# Altium schematic sheet Power_Relay.SchDoc
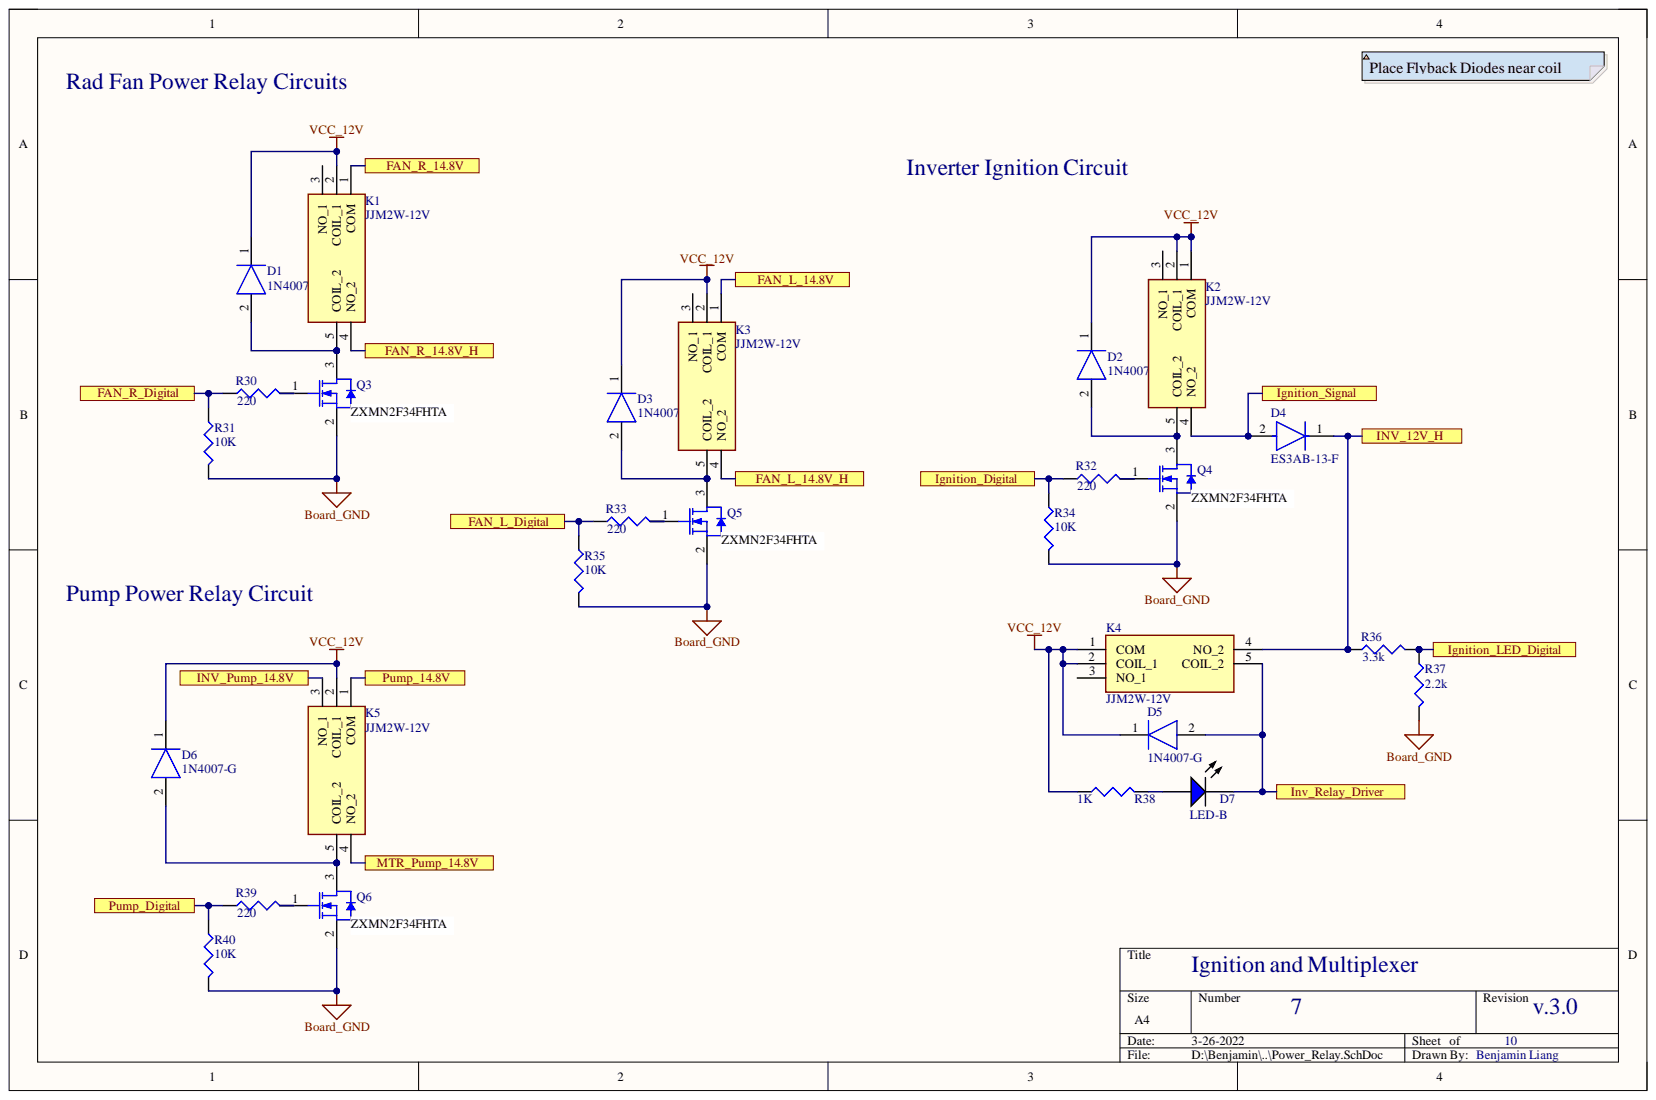
[diagram p0: Power_Relay.SchDoc]

## Parts
D1  1N4007-G  [DIOAD1410W90L470D235]
D2  1N4007-G  [DIOAD1410W90L470D235]
D3  1N4007-G  [DIOAD1410W90L470D235]
D4  ES3AB-13-F  [FP-SMB-MFG]
D5  1N4007-G  [DIOAD1410W90L470D235]
D6  1N4007-G  [DIOAD1410W90L470D235]
D7  LED-B  [LTST-C193TBKT_V]
K1  JJM2W-12V  [JJM2W12V]
K2  JJM2W-12V  [JJM2W12V]
K3  JJM2W-12V  [JJM2W12V]
K4  JJM2W-12V  [JJM2W12V]
K5  JJM2W-12V  [JJM2W12V]
Q3  ZXMN2F34FHTA  [FAIR-SSOT3L-3_V]
Q4  ZXMN2F34FHTA  [FAIR-SSOT3L-3_V]
Q5  ZXMN2F34FHTA  [FAIR-SSOT3L-3_V]
Q6  ZXMN2F34FHTA  [FAIR-SSOT3L-3_V]
R30  220  [J1-0603]
R31  10K  [J1-0603]
R32  220  [J1-0603]
R33  220  [J1-0603]
R34  10K  [J1-0603]
R35  10K  [J1-0603]
R36  3.3k  [J1-0603]
R37  2.2k  [J1-0603]
R38  1K  [J1-0603]
R39  220  [J1-0603]
R40  10K  [J1-0603]

## Ports
FAN_L_14.8V, FAN_L_14.8V_H, FAN_L_Digital, FAN_R_14.8V, FAN_R_14.8V_H, FAN_R_Digital, Ignition_Digital, Ignition_LED_Digital, Ignition_Signal, INV_12V_H, INV_Pump_14.8V, Inv_Relay_Driver, MTR_Pump_14.8V, Pump_14.8V, Pump_Digital

## Nets
Board_GND: Q3.2 Q4.2 Q5.2 Q6.2 R31.2 R34.2 R35.2 R37.2 R40.2
VCC_12V: D1.1 D2.1 D3.1 D5.1 D6.1 K1.2(COIL_1) K2.1(COM) K2.2(COIL_1) K3.2(COIL_1) K4.1(COM) K4.2(COIL_1) K5.2(COIL_1) R38.1
FAN_L_14.8V: K3.1(COM) ; port FAN_L_14.8V
FAN_L_14.8V_H: K3.4(NO_2) ; port FAN_L_14.8V_H
FAN_L_Digital: R33.1 R35.1 ; port FAN_L_Digital
FAN_R_14.8V: K1.1(COM) ; port FAN_R_14.8V
FAN_R_14.8V_H: K1.4(NO_2) ; port FAN_R_14.8V_H
FAN_R_Digital: R30.1 R31.1 ; port FAN_R_Digital
Ignition_Digital: R32.1 R34.1 ; port Ignition_Digital
Ignition_LED_Digital: R36.2 R37.1 ; port Ignition_LED_Digital
Ignition_Signal: D4.2 K2.4(NO_2) ; port Ignition_Signal
INV_12V_H: D4.1 K4.4(NO_2) R36.1 ; port INV_12V_H
INV_Pump_14.8V: K5.3(NO_1) ; port INV_Pump_14.8V
Inv_Relay_Driver: D5.2 D7.2 K4.5(COIL_2) ; port Inv_Relay_Driver
MTR_Pump_14.8V: K5.4(NO_2) ; port MTR_Pump_14.8V
Pump_14.8V: K5.1(COM) ; port Pump_14.8V
Pump_Digital: R39.1 R40.1 ; port Pump_Digital
NetD1_2: D1.2 K1.5(COIL_2) Q3.3
NetD2_2: D2.2 K2.5(COIL_2) Q4.3
NetD3_2: D3.2 K3.5(COIL_2) Q5.3
NetD6_2: D6.2 K5.5(COIL_2) Q6.3
NetD7_1: D7.1 R38.2
NetQ3_1: Q3.1 R30.2
NetQ4_1: Q4.1 R32.2
NetQ5_1: Q5.1 R33.2
NetQ6_1: Q6.1 R39.2

Not connected: K1 3(NO_1); K2 3(NO_1); K3 3(NO_1); K4 3(NO_1)

## Library data of the parts
D1-D3 D5 D6 = 1N4007-G: Diode; Manufacturer_Name: Comchip Technology; Arrow Part Number: 1N4007-G; Arrow Price/Stock: https://www.arrow.com/en/products/1n4007-g/comchip-technology?region=nac; Component Kind: Standard; Datasheet Link: https://datasheet.datasheetarchive.com/originals/distributors/Datasheets-DGA23/1390217.pdf; Manufacturer_Part_Number: 1N4007-G; Mouser Part Number: 750-1N4007-G; Mouser Price/Stock: https://www.mouser.co.uk/ProductDetail/Comchip-Technology/1N4007-G?qs=2qJf6qQ4IOKzApuByvlhjQ%3D%3D
D4 = CMP-12791-000010-1: DIODE GEN PURP 50V 3A SMB; Manufacturer: Diodes; Capacitance: 45pF; Max Junction Temp: +150°C; Max Surge Forward Current: 100A; Peak Reverse Current: 10uA; Standoff Height: 0.05mm; Value: 45pF; Automotive: No; Category: Diode; Component Kind: Standard; ComponentLink2Description: Datasheet; ComponentLink2URL: https://forum.upverter.com/uploads/short-url/2fx1zdCm0X7KnJF0hsWL21N8TrX.pdf; Device Class L1: Discrete Semiconductors; Device Class L2: Diodes; Device Class L3: Rectifier Diodes; Digikey Description: DIODE GEN PURP 50V 3A SMB; Footprint Url: https://www.diodes.com/assets/Package-Files/SMB.pdf; Forward Current: 3A; Height: 2.5mm; Lead Free: Yes; Library Name: Altium Content Vault; Manufacturer Part Number: ES3AB-13-F; Max Repetitive Reverse Voltage: 50V; Mouser Description: Rectifiers 50V 3A; Octopart: https://octopart.com/es3ab-13-f-diodes+inc.-328916; Package: SMB; Reverse Voltage: 50V; RoHS: TRUE; Temperature: -55°C to +150°C; Temperature Range High: +150°C; Temperature Range Low: -55°C
D7 = CMP-2000-06624-1: LED Uni-Color Blue, 76 mW, 20 mA, -20 to 80 degC, 2-Pin SMD, RoHS, Tape and Reel  LTST-C193TBKT-5A; Brand: Lite-On; Forward Current: 5mA; Forward Voltage: 2.8V; LED Size: 1.6mmx0.8mmx0.35mm; Luminous Intensity: 15mcd; Viewing Angle: 130deg; Wavelength/Color Temperature: 465nmto475nm; Component Kind: Standard; Datasheet URL: https://datasheet.ciiva.com/15201/getdatasheetpartid-293056-15201395.pdf; Datasheet Version: 08/2011; Factory Pack Quantity: 3000; Illumination Color: Blue; Library Name: Altium Content Vault; Manufacturer: Lite-On; Manufacturer URL: http://www.us.liteon.com/; Maximum Operating Temperature: +80C; Minimum Operating Temperature: -20C; Mounting Technology: SurfaceMount; Package / Case: 0603; Package Description: 2-Pin Surface Mount Device, Body 0.8 x 1.6 mm; Package Reference: C193TBKT; Packaging: Reel; Product Category: StandardLEDs-SMD; RoHS: Details
K1-K5 = JJM2W-12V: Relay or Contactor; Manufacturer_Name: Panasonic; Arrow Part Number: JJM2W-12V; Arrow Price/Stock: https://www.arrow.com/en/products/jjm2w-12v/panasonic; Component Kind: Standard; Datasheet Link: https://componentsearchengine.com/Datasheets/1/JJM2w-12V.pdf; Height: 14mm; Manufacturer_Part_Number: JJM2W-12V; Mouser Part Number: 769-JJM2W-12V; Mouser Price/Stock: https://www.mouser.com/Search/Refine.aspx?Keyword=769-JJM2W-12V
Q3-Q6 = CMP-2000-06249-1: Manufacturer: FairchildSemiconductor; Channel Mode: Enhancement; Channel Type: N; Maximum Continuous Drain Current: 1.7A; Maximum Drain Source Voltage: 20V; Maximum Gate Source Voltage: ±8V; Package Version: Rev. 3, 05/2015; Rad Hard: No; RDS-on: 0.07@4.5VOhm; Typical Fall Time: 3ns; Typical Rise Time: 8.5ns; Typical Turn-Off Delay Time: 11ns; Typical Turn-On Delay Time: 5ns; Category: MOSFET; Component Kind: Standard; Datasheet URL: https://www.fairchildsemi.com/datasheets/FD/FDN335N.pdf; Datasheet Version: Rev. C, 04/1999; Description: ZXMN2F34FHTA; Library Name: Altium Content Vault; Manufacturer URL: https://www.fairchildsemi.com/; Mounting: SurfaceMount; Mounting Technology: SurfaceMount; Operating Temperature: -55to150°C; Package: 3SuperSOT; Package Description: 3-Pin Small Outline Transistor, Body 2.92 x 1.4 mm, Pitch 0.95 mm; Package Reference: SSOT3L; Package URL: https://www.fairchildsemi.com/package-drawings/MA/MA03B.pdf; Packaging: Tape&Reel
R30 R32 R33 R36-R39 = Res3: Resistor; Value: 10K; Component Kind: Standard; LatestRevisionDate: 29-May-2009; LatestRevisionNote: IPC-7351 Footprint Added.; Library Name: Miscellaneous Devices.IntLib; PackageDescription: Chip Resistor; PackageReference: J1-0603; Published: 8-Jun-2000; Signal Integrity: Res; Simulation: RESISTOR; Publisher: Altium Limited
R31 R34 R35 R40 = Res3: Resistor; Component Kind: Standard; LatestRevisionDate: 29-May-2009; LatestRevisionNote: IPC-7351 Footprint Added.; Library Name: Miscellaneous Devices.IntLib; PackageDescription: Chip Resistor; PackageReference: J1-0603; Published: 8-Jun-2000; Signal Integrity: Res; Simulation: RESISTOR; Value: 10K; Publisher: Altium Limited
